# Altium schematic sheet 01 ESP32 MV.SchDoc
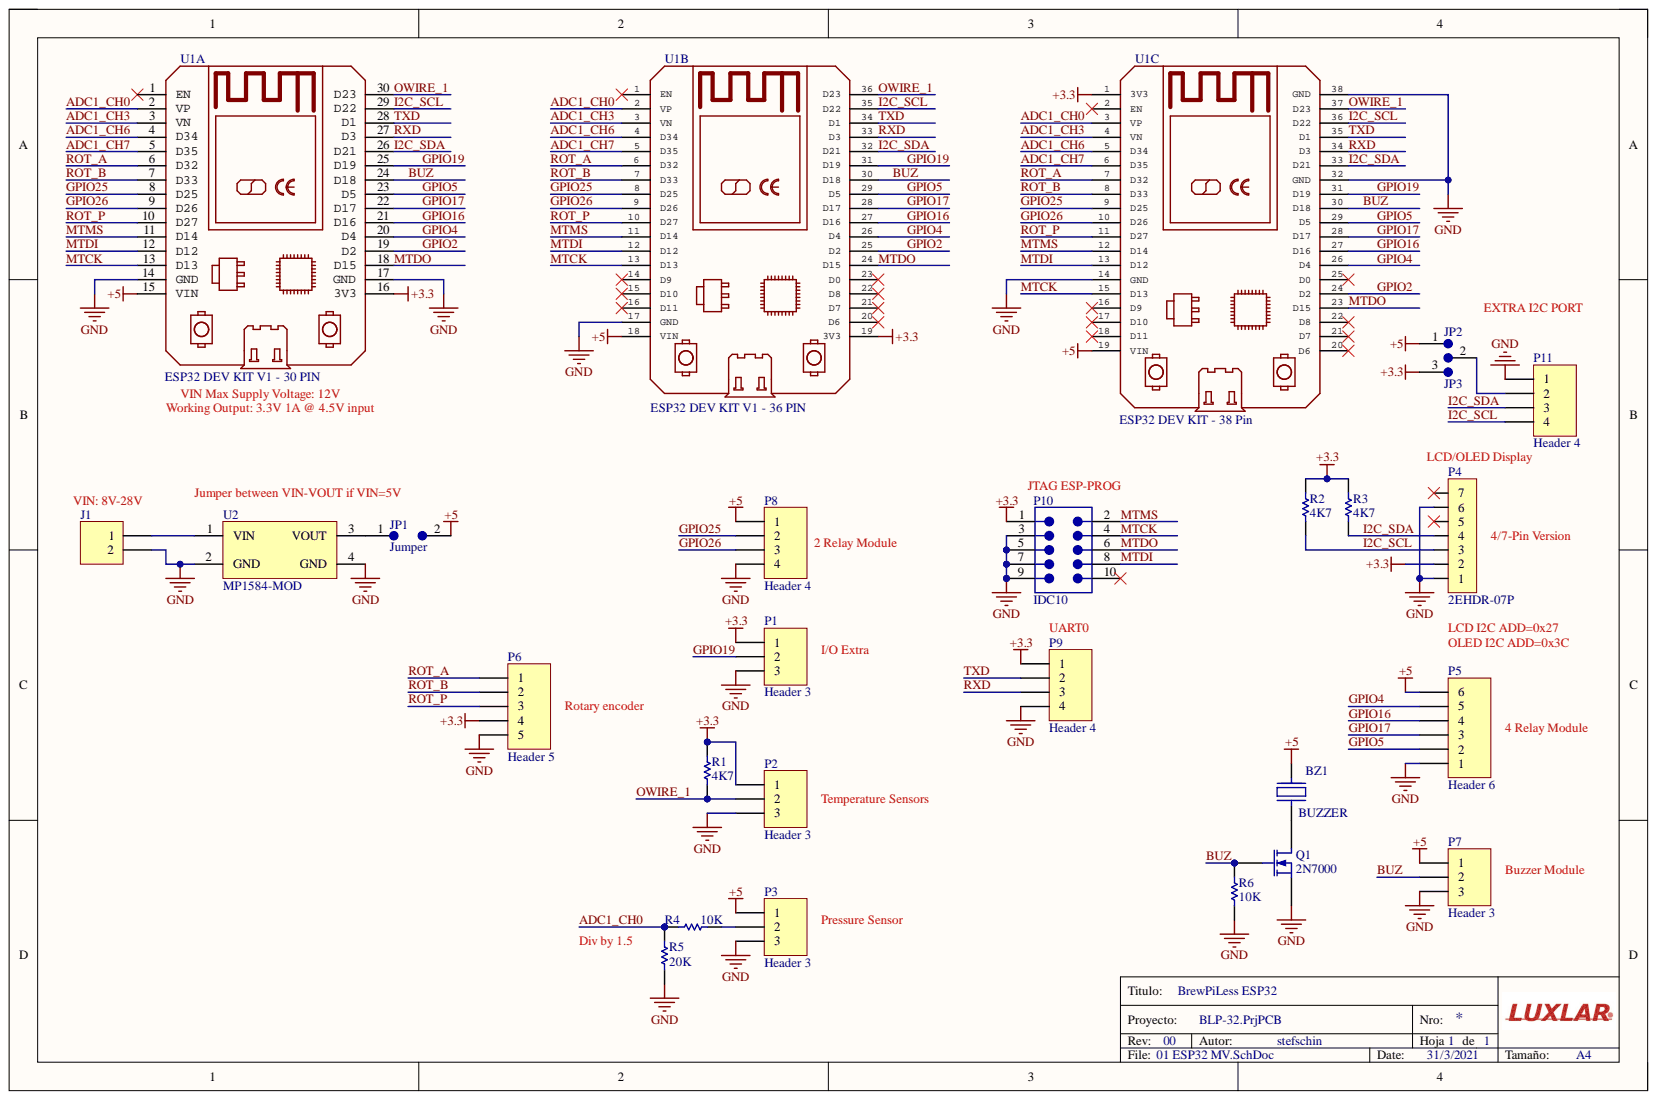
[diagram p0: 01 ESP32 MV.SchDoc]

## Parts
BZ1  BUZZER  [BUZZER]
J1  Header 2  [TSx2-5.08]  (value not drawn)
JP1  Jumper  [IDC2]
JP2  JP3  [JUMPERx3]  (no BOM)
P1  Header 3  [TSx3-5.08]
P2  Header 3  [TSx3-5.08]
P3  Header 3  [TSx3-5.08]
P4  2EHDR-07P  [HDR1X7]
P5  Header 6  [HDR1X6]
P6  Header 5  [HDR1X5]
P7  Header 3  [HDR1X3]
P8  Header 4  [HDR1X4]
P9  Header 4  [HDR1X4]
P10  IDC10  [IDC10 - SH]
P11  Header 4  [HDR1X4]
Q1  2N7000  [TO-92W]
R1  4K7  [AXIAL-0.3]
R2  4K7  [AXIAL-0.3]
R3  4K7  [AXIAL-0.3]
R4  10K  [AXIAL-0.3]
R5  20K  [AXIAL-0.3]
R6  10K  [AXIAL-0.3]
U1A  ESP32 DEV KIT V1 - 30 PIN  [ESP32-DEVKITV1-30A]
U1B  ESP32 DEV KIT V1 - 36 PIN  [ESP32-DEVKITV1-36A]
U1C  ESP32 DEV KIT - 38 Pin  [ESP32-DEVKITV1-38A]
U2  MP1584-MOD  [MP1584-MOD]

## Nets
+3.3: JP2.3 P1.1 P2.1 P4.2 P6.4 P9.1 P10.1 R1.1 R2.1 R3.1 U1A.16(3V3) U1B.19(3V3) U1C.1(3V3)
+5: BZ1.1 JP1.2 JP2.1 P3.1 P5.6 P7.1 P8.1 U1A.15(VIN) U1B.18(VIN) U1C.19(VIN)
GND: J1.2 P1.3 P2.3 P3.3 P4.1 P4.6 P5.1 P6.5 P7.3 P8.4 P9.4 P10.3 P10.5 P10.7 P10.9 P11.1 Q1.1 R5.2 R6.2 U1A.14(GND) U1A.17(GND) U1B.17(GND) U1C.14(GND) U1C.32(GND) U1C.38(GND) U2.2(GND) U2.4(GND)
ADC1_CH0: R4.1 R5.1 U1A.2(VP) U1B.2(VP) U1C.3(VP)
ADC1_CH3: U1A.3(VN) U1B.3(VN) U1C.4(VN)
ADC1_CH6: U1A.4(D34) U1B.4(D34) U1C.5(D34)
ADC1_CH7: U1A.5(D35) U1B.5(D35) U1C.6(D35)
BUZ: P7.2 Q1.2 R6.1 U1A.24(D18) U1B.30(D18) U1C.30(D18)
GPIO2: U1A.19(D2) U1B.25(D2) U1C.24(D2)
GPIO4: P5.5 U1A.20(D4) U1B.26(D4) U1C.26(D4)
GPIO5: P5.2 U1A.23(D5) U1B.29(D5) U1C.29(D5)
GPIO16: P5.4 U1A.21(D16) U1B.27(D16) U1C.27(D16)
GPIO17: P5.3 U1A.22(D17) U1B.28(D17) U1C.28(D17)
GPIO19: P1.2 U1A.25(D19) U1B.31(D19) U1C.31(D19)
GPIO25: P8.2 U1A.8(D25) U1B.8(D25) U1C.9(D25)
GPIO26: P8.3 U1A.9(D26) U1B.9(D26) U1C.10(D26)
I2C_SCL: P4.3 P11.4 R2.2 U1A.29(D22) U1B.35(D22) U1C.36(D22)
I2C_SDA: P4.4 P11.3 R3.2 U1A.26(D21) U1B.32(D21) U1C.33(D21)
MTCK: P10.4 U1A.13(D13) U1B.13(D13) U1C.15(D13)
MTDI: P10.8 U1A.12(D12) U1B.12(D12) U1C.13(D12)
MTDO: P10.6 U1A.18(D15) U1B.24(D15) U1C.23(D15)
MTMS: P10.2 U1A.11(D14) U1B.11(D14) U1C.12(D14)
OWIRE_1: P2.2 R1.2 U1A.30(D23) U1B.36(D23) U1C.37(D23)
ROT_A: P6.1 U1A.6(D32) U1B.6(D32) U1C.7(D32)
ROT_B: P6.2 U1A.7(D33) U1B.7(D33) U1C.8(D33)
ROT_P: P6.3 U1A.10(D27) U1B.10(D27) U1C.11(D27)
RXD: P9.3 U1A.27(D3) U1B.33(D3) U1C.34(D3)
TXD: P9.2 U1A.28(D1) U1B.34(D1) U1C.35(D1)
NetBZ1_2: BZ1.2 Q1.3
NetJ1_1: J1.1 U2.1(VIN)
NetJP1_1: JP1.1 U2.3(VOUT)
NetJP2_2: JP2.2 P11.2
NetP3_2: P3.2 R4.2

Not connected: P4 5 7; P10 10; U1A 1(EN); U1B 1(EN) 14(D9) 15(D10) 16(D11) 20(D6) 21(D7) 22(D8) 23(D0); U1C 2(EN) 16(D9) 17(D10) 18(D11) 20(D6) 21(D7) 22(D8) 25(D0)

## Library data of the parts
BZ1 = BUZZER: BUZZER 5V; Manufacturer: Soberton; Manufacturer Part Number: WST-1203UX; Frequency: 2.3kHz; Operating Supply Current: 30mA; Sensing Method: Magnetic; Voltage Rating: 3V; Component Kind: Standard; ComponentLink1Description: https://datasheet.ciiva.com/0/wst-1203ux-419.pdf?src-supplier=Digi-Key; ComponentLink1URL: https://datasheet.ciiva.com/0/wst-1203ux-419.pdf?src-supplier=Digi-Key; Height: 7.7mm; Lead Free: Lead Free; Max Operating Temperature: 70°C; Min Operating Temperature: -20°C; Mount: Through Hole; Packaging: Tray; Pricing 1: 1=1,40000, 10=1,16900, 25=1,08160, 50=1,01800, 100=0,95670, 500=0,82308, 1000=0,76943, 5000=0,75400 (USD); RoHS: Yes; Stock 1: 39053; Supplier 1: Digi-Key; Supplier Part Number 1: 433-1047-ND; Termination: PC Pins
J1 = Header 2: Header, 2-Pin; Component Kind: Standard; LatestRevisionDate: 17-Jul-2002; LatestRevisionNote: Re-released for DXP Platform.; Library Name: Miscellaneous Connectors.IntLib; PCB3D: HEADER_2_5; Signal Integrity: Connector; Publisher: Altium Limited
JP2 = JP3_1: Jumper x 3 SMD; Library Name: CONECTORES.SCHLIB
P1-P3 P7 = Header 3: Header, 3-Pin; Value: Bornera; Component Kind: Standard; LatestRevisionDate: 17-Jul-2002; LatestRevisionNote: Re-released for DXP Platform.; Library Name: Miscellaneous Connectors.IntLib; Signal Integrity: Connector; Publisher: Altium Limited
P4 = 2EHDR-07P: 2EHDR-07P BORNES DINKLE 7 VIAS; CODIGO: JCDEERP07G; Component Kind: Standard; Library Name: CONECTORES.SCHLIB
P5 = Header 6: Header, 6-Pin; Component Kind: Standard; LatestRevisionDate: 17-Jul-2002; LatestRevisionNote: Re-released for DXP Platform.; Library Name: Miscellaneous Connectors.IntLib; Signal Integrity: Connector; Publisher: Altium Limited
P6 = Header 5: Header, 5-Pin; Component Kind: Standard; LatestRevisionDate: 17-Jul-2002; LatestRevisionNote: Re-released for DXP Platform.; Library Name: Miscellaneous Connectors.IntLib; Signal Integrity: Connector; Publisher: Altium Limited
P8 P9 P11 = Header 4H: Header, 4-Pin, Right Angle; Descripción: CONECTOR 7600-4PRT MA-04 HORIZ.P=2.5MM; Hermes: 3.02.03.0270; Component Kind: Standard; Library Name: Miscellaneous Connectors.IntLib; Signal Integrity: Connector; Value: Header 4H
P10 = IDC10A: CONECTOR IDC HEMBRA PCB NO POLARIZADO PASO 2.54 mm RECTO 2X5 VIAS; CODIGO: JNXJDSP10G; Component Kind: Standard; Library Name: CONECTORES.SCHLIB
Q1 = 2N7000: N-Channel Enhancement Mode Vertical DMOS FET; Component Kind: Standard; ComponentLink1Description: Manufacturer Link; ComponentLink1URL: http://www.zetex.com/; DatasheetVersion: Nov-1994; LatestRevisionDate: 17-Jul-2002; LatestRevisionNote: Re-released for DXP Platform.; Library Name: Zetex Discrete MOSFET.IntLib; PackageDescription: TO, Flat Index; 3 In-Line, Axial Leads; Body Dia. 4.7mm; Leads 0.56 x 0.51 mm (max); PackageReference: T092; PackageVersion: 1995; PCB3D: TO-92; Published: 8-Jun-2000; Simulation: 2N7000; Publisher: Altium Limited
R1-R6 = Res1: Resistor; Value: 1K; Component Kind: Standard; LatestRevisionDate: 17-Jul-2002; LatestRevisionNote: Re-released for DXP Platform.; Library Name: Miscellaneous Devices.IntLib; PackageReference: AXIAL-0.3; PCB3D: RES_300; Published: 8-Jun-2000; Signal Integrity: Res; Simulation: RESISTOR; Publisher: Altium Limited
U1A = ESP32DEVKITV1-30: ESP32 DOIT DEVKIT V1 Board 30 Pins; GateName_1: G$1; SymbolName_1: ESP32-DEVKITV1; Component Kind: Standard; DeviceSetName: ESP32DEVKITV1; Library Name: IOT LIB.SchLib; LibraryName: ESP32-DEVKITV1; Package: ESP32-DEVKITV1
U1B = ESP32DEVKITV1-36: ESP32 DOIT DEVKIT V1 Board 36 Pins; GateName_1: G$1; SymbolName_1: ESP32-DEVKITV1; Component Kind: Standard; DeviceSetName: ESP32DEVKITV1; Library Name: IOT LIB.SchLib; LibraryName: ESP32-DEVKITV1; Package: ESP32-DEVKITV1
U1C = ESP32DEVKITV1-38: ESP32 DEVKIT Board 38 Pins; GateName_1: G$1; SymbolName_1: ESP32-DEVKITV1; Component Kind: Standard; DeviceSetName: ESP32DEVKITV1; Library Name: IOT LIB.SchLib; LibraryName: ESP32-DEVKITV1; Package: ESP32-DEVKITV1
U2 = MP1584-MOD: ADJUSTABLE STEP-DOWN DC/DC CONVERTER; Manufacturer: Seeed Studio; Manufacturer Part Number: 106990005; Output Current: 3A; Output Voltage: 18V; Component Kind: Standard; ComponentLink1Description: http://wiki.seeedstudio.com/images/4/4e/MP1584_r1.0.pdf?src-supplier=Digi-Key; ComponentLink1URL: http://wiki.seeedstudio.com/images/4/4e/MP1584_r1.0.pdf?src-supplier=Digi-Key; Library Name: IOT LIB.SchLib; Pricing 1: 8=4.59 (USD); Stock 1: 0; Supplier 1: Digi-Key; Supplier Part Number 1: 106990005-ND
JP1 = Jumper: Component Kind: Standard; Description: Jumper; Library Name: CONECTORES.SCHLIB
